# Altium schematic sheet Digital Control.SchDoc("Digital Control")
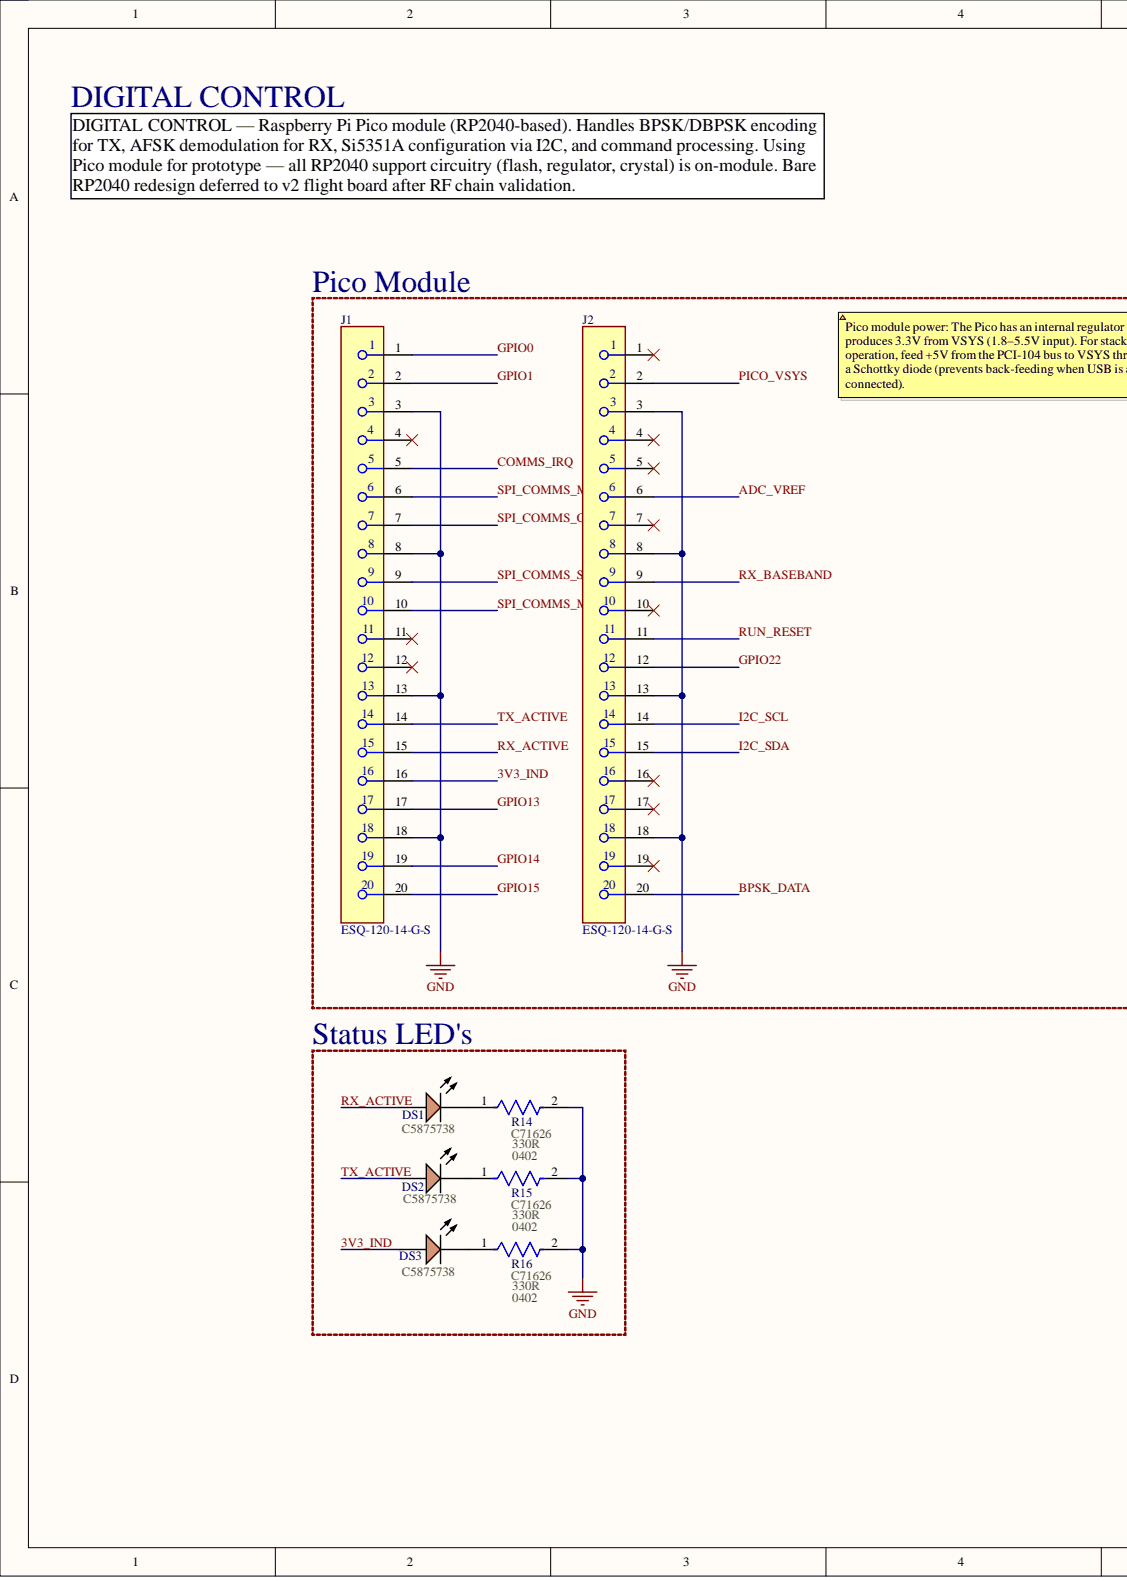
[diagram p0: Digital Control.SchDoc, left]
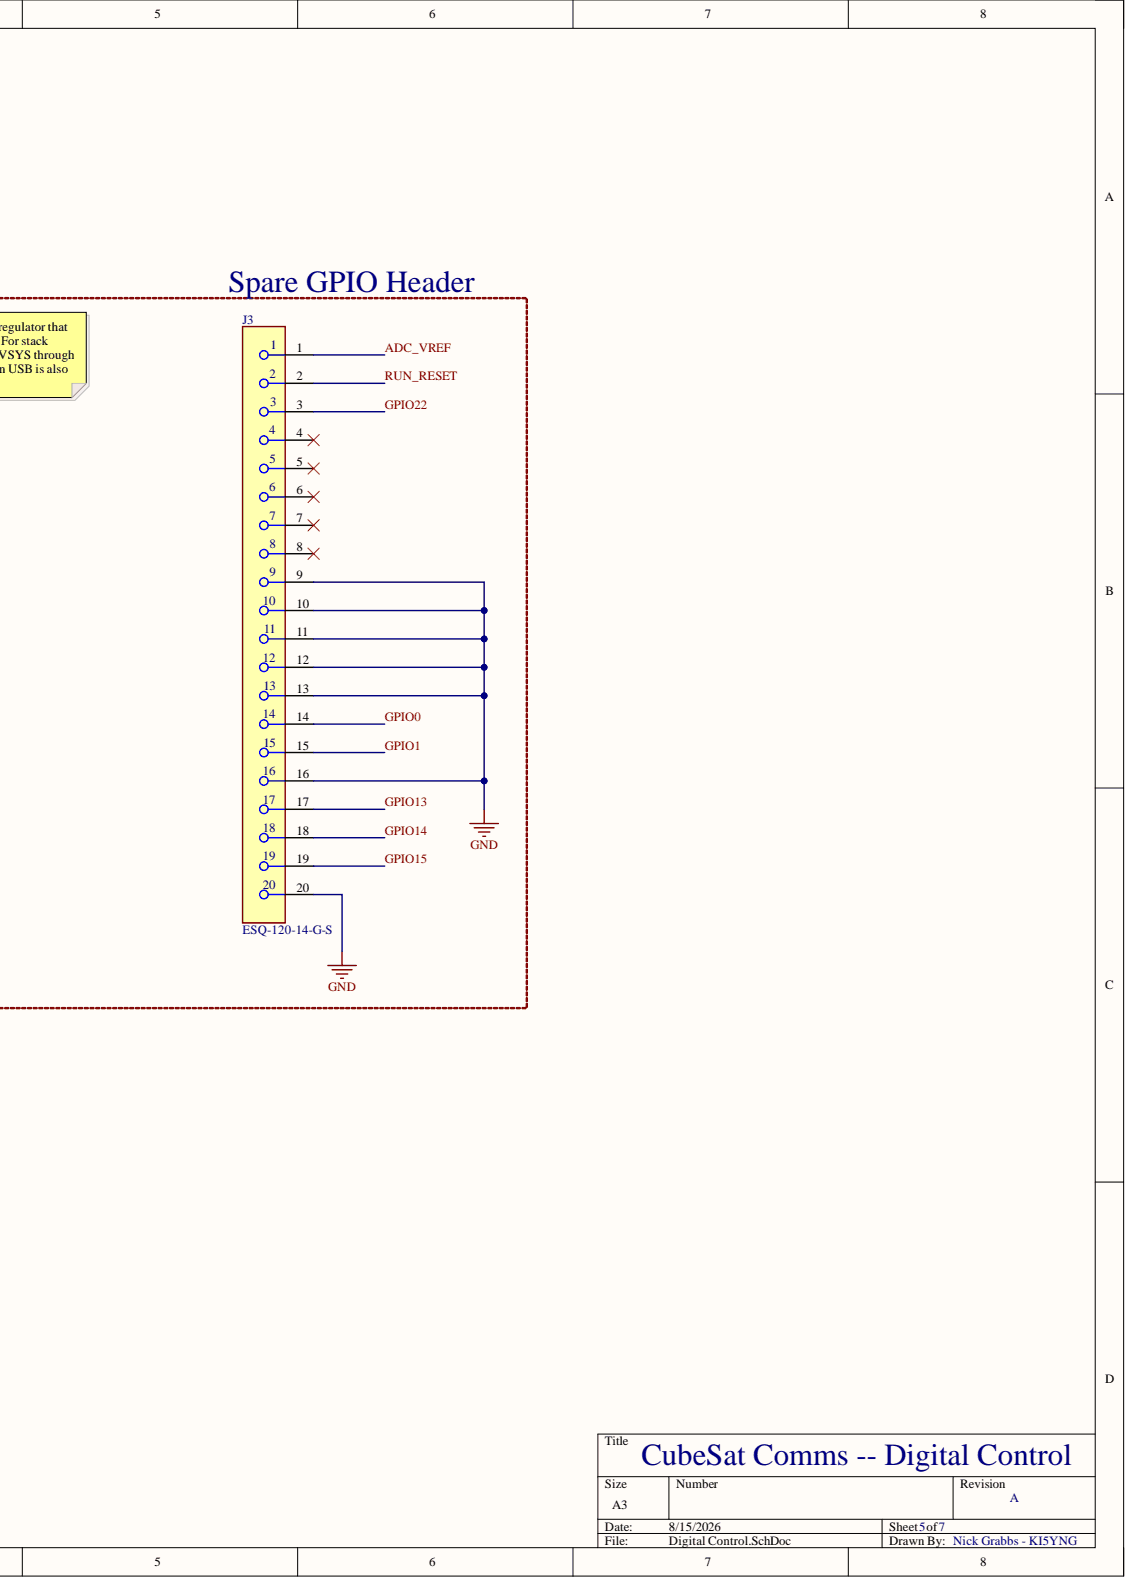
[diagram p1: Digital Control.SchDoc, right]

## Parts
DS1  LCSC Part #: C5875738  (hidden comment: APG1608SEKC/T)
DS2  LCSC Part #: C5875738  (hidden comment: APG1608SEKC/T)
DS3  LCSC Part #: C5875738  (hidden comment: APG1608SEKC/T)
J1  ESQ-120-14-G-S
J2  ESQ-120-14-G-S
J3  ESQ-120-14-G-S
R14  LCSC Part #: C71626  Resistance: 330R  (hidden comment: CRCW0402330RFKED)
R15  Case Code (Imperial): 0402  LCSC Part #: C71626  Resistance: 330R  (hidden comment: CRCW0402330RFKED)
R16  Case Code (Imperial): 0402  LCSC Part #: C71626  Resistance: 330R  (hidden comment: CRCW0402330RFKED)

## Nets
GND: J1.3 J1.8 J1.13 J1.18 J2.3 J2.8 J2.13 J2.18 J3.9 J3.10 J3.11 J3.12 J3.13 J3.16 J3.20 R14.2 R15.2 R16.2
3V3_IND: DS3.1 J1.16
ADC_VREF: J2.6 J3.1
BPSK_DATA: J2.20
COMMS_IRQ: J1.5
GPIO0: J1.1 J3.14
GPIO1: J1.2 J3.15
GPIO13: J1.17 J3.17
GPIO14: J1.19 J3.18
GPIO15: J1.20 J3.19
GPIO22: J2.12 J3.3
I2C_SCL: J2.14
I2C_SDA: J2.15
PICO_VSYS: J2.2
RUN_RESET: J2.11 J3.2
RX_ACTIVE: DS1.1 J1.15
RX_BASEBAND: J2.9
SPI_COMMS_CS_N: J1.7
SPI_COMMS_MISO: J1.6
SPI_COMMS_MOSI: J1.10
SPI_COMMS_SCK: J1.9
TX_ACTIVE: DS2.1 J1.14
NetDS1_2: DS1.2 R14.1
NetDS2_2: DS2.2 R15.1
NetDS3_2: DS3.2 R16.1

Not connected: J1 4 11 12; J2 1 4 5 7 10 16 17 19; J3 4 5 6 7 8

## Library data of the parts
DS1-DS3 = CMP-0239-00002-1: LED, SMT, 0603(1608), 0.25mm Tthickness, Super Bright Orange; Forward Current: 30 mA; Packing: Reel; Power Dissipation: 75 mW; Reverse Voltage: 5 V; Component Kind: Standard; ComponentLink1Description: Manufacturer URL; ComponentLink1URL: http://www.kingbrightusa.com/; ComponentLink2Description: Datasheet; ComponentLink2URL: http://www.kingbrightusa.com/images/catalog/SPEC/APG1608SEKC-T.pdf; DatasheetVersion: Rev. 4A, 12/2011; Library Name: Altium Content Vault; Mounting Technology: Surface Mount; PackageDescription: 2-Pin SMT LED, 1.6 x 0.8 mm Body, 0.25mm Thickness; PackageReference: LED0603-25-ORANGE; PackageVersion: Rev. 4A, 12/2011; PartNumber: APG1608SEKC/T; Storage Temperature: -40 to 85 degC
J1-J3 = CMP-02766-000124-1: CONN SOCKET 20POS 0.1 GOLD PCB; Connector Type: Receptacle; Contact Resistance: 10mR; Max Current Rating: 6.9A; Max Voltage Rating (AC): 550V; Number of Contacts: 20; Pitch: 2.54mm; Color: Black; Component Kind: Standard; Contact Material: Bronze; Contact Plating: Gold; Depth: 2.41mm; Gender: Receptacle; Housing Color: Black; Housing Material: Polyester; Length: 51.31mm; Library Name: Altium Content Vault; Max Operating Temperature: 125°C; Min Operating Temperature: -55°C; Mount: Through Hole; Number of Positions: 20; Number of Rows: 1; Orientation: Straight; Packaging: Rail/Tube; Radiation Hardening: No; REACH SVHC: No SVHC; Termination: Solder
R14-R16 = CMP-2002-08523-2: RES Thick Film, 330Ω, 1%, 0.063W, 100ppm/°C, 0402; Max Power Dissipation: 63mW; Voltage Rating: 50V; Case Code (Metric): 1005; Case/Package: 0402; Component Kind: Standard; Composition: Thick Film; Contact Plating: Tin; Depth: 0.5mm; Features: Automotive AEC-Q200; Height: 0.35mm; Lead Free: Lead Free; Length: 1mm; Library Name: Altium Content Vault; Max Operating Temperature: 155°C; Military Standard: Not; Min Operating Temperature: -55°C; Number of Terminations: 2; Package Quantity: 10; Packaging: Tape and Reel; Power Rating: 63mW; Radiation Hardening: No; Temperature Coefficient: 100ppm/°C; Termination: SMD/SMT; Tolerance: 1%; Voltage Rating (DC): 50V; Weight: 2.3E-05oz; Width: 0.5mm
  R14: Case Code (Imperial): 0402
